# Altium schematic sheet GW1N.SchDoc(GW1N)
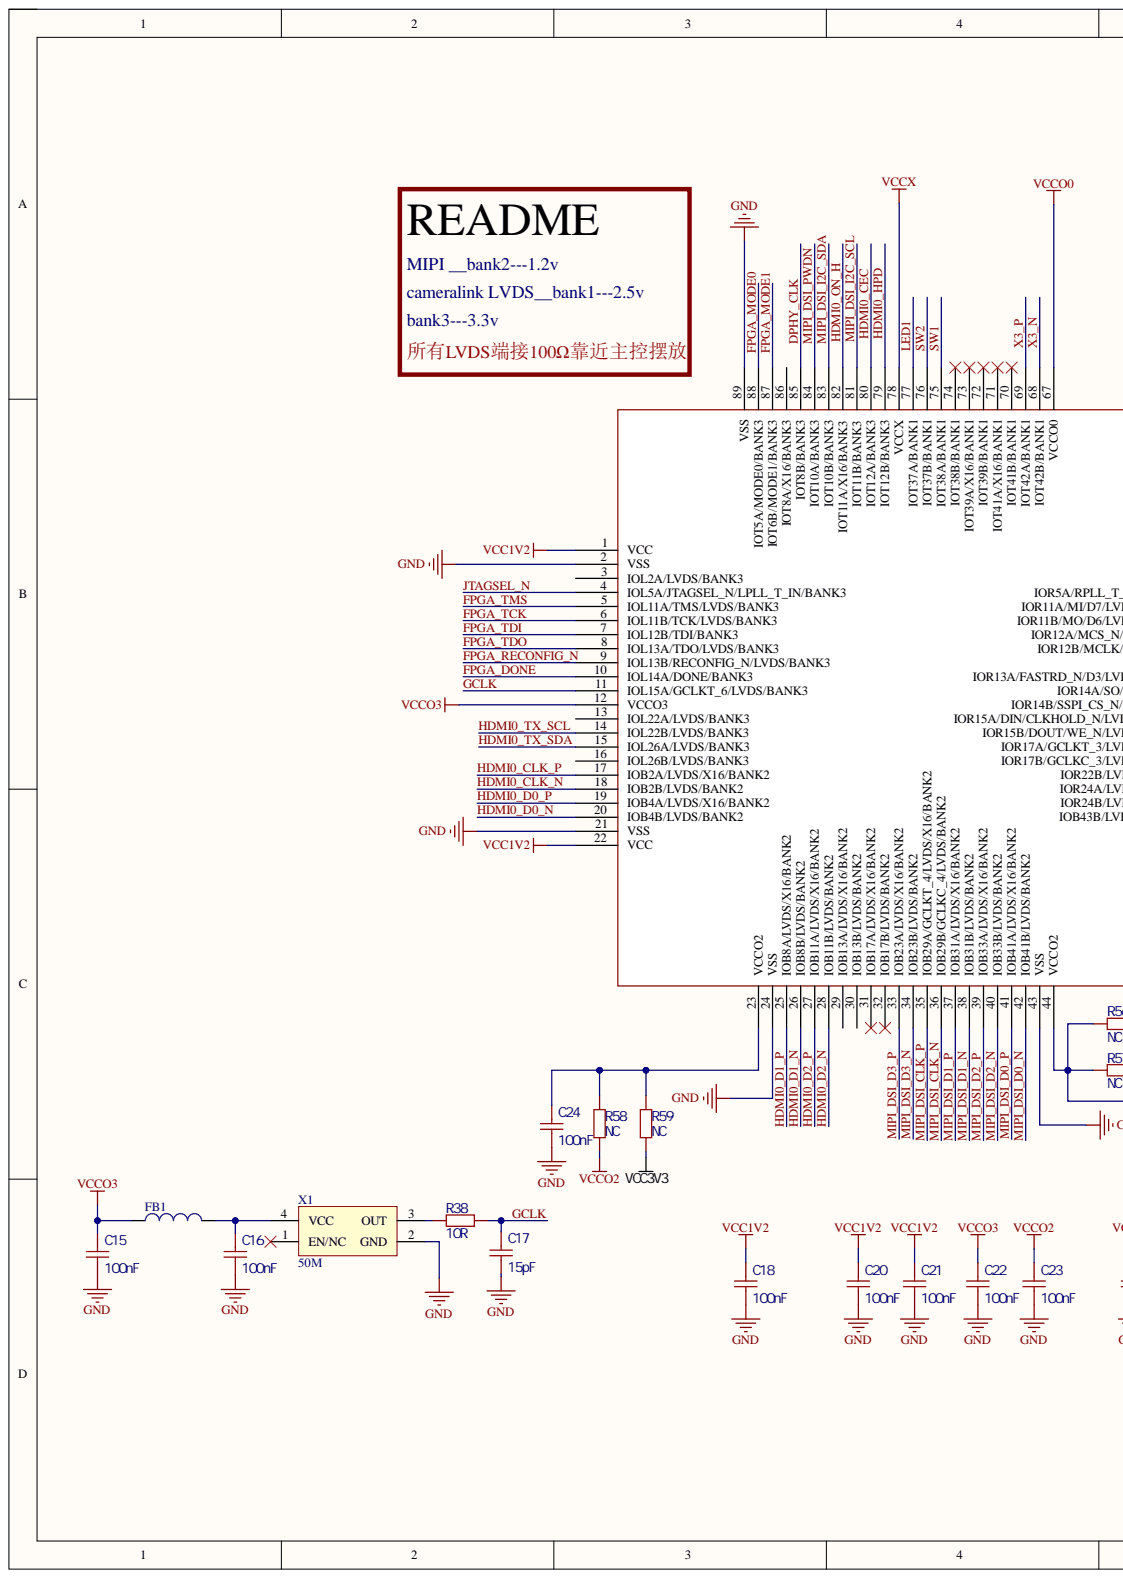
[diagram p0: GW1N.SchDoc, left]
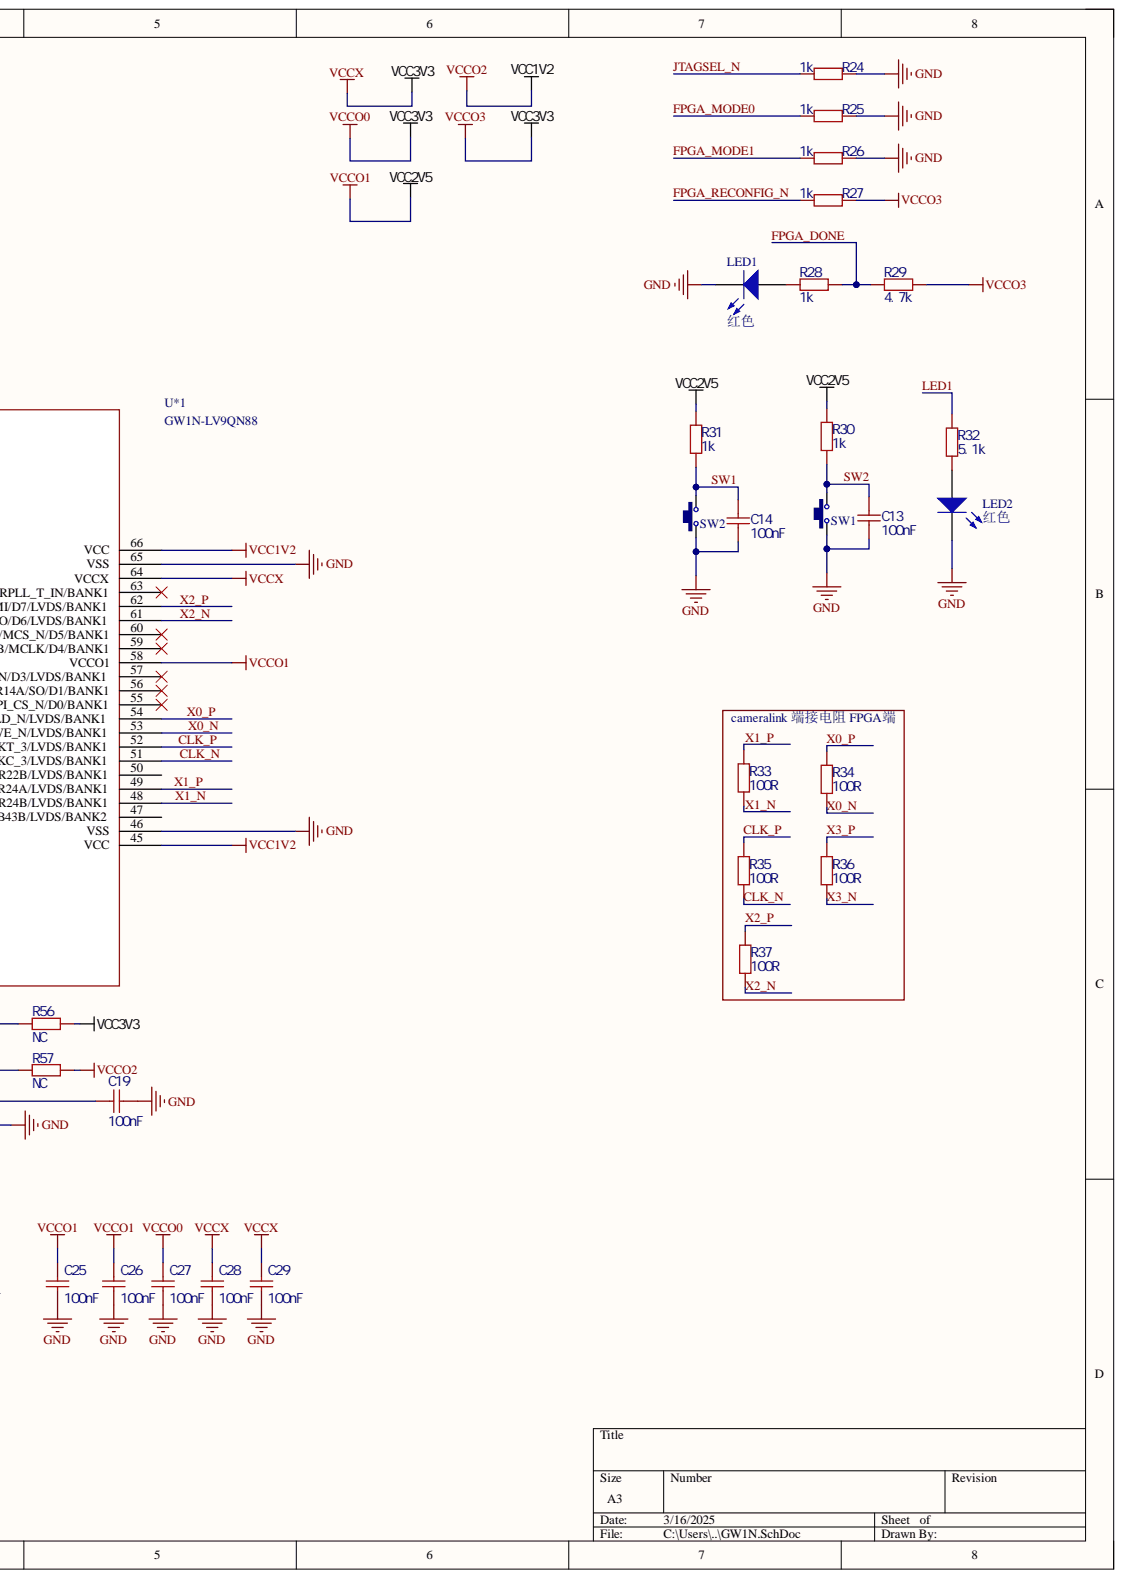
[diagram p1: GW1N.SchDoc, right]

## Parts
C13  100nF  [0402]
C14  100nF  [0402]
C15  100nF  [0402]
C16  100nF  [0402]
C17  15pF  [0402]
C18  100nF  [0402]
C19  100nF  [0402]
C20  100nF  [0402]
C21  100nF  [0402]
C22  100nF  [0402]
C23  100nF  [0402]
C24  100nF  [0402]
C25  100nF  [0402]
C26  100nF  [0402]
C27  100nF  [0402]
C28  100nF  [0402]
C29  100nF  [0402]
FB1  Inductor  [1608[0603]]  (value not drawn)
LED1  红色  [LED0805_G]
LED2  红色  [LED0805_G]
R24  1k  [0402]
R25  1k  [0402]
R26  1k  [0402]
R27  1k  [0402]
R28  1k  [0402]
R29  4.7k  [0402]
R30  1k  [0402]
R31  1k  [0402]
R32  5.1k  [0402]
R33  100R  [0402]
R34  100R  [0402]
R35  100R  [0402]
R36  100R  [0402]
R37  100R  [0402]
R38  10R  [0402]
R56  NC  [1608[0603]]
R57  NC  [1608[0603]]
R58  NC  [1608[0603]]
R59  NC  [1608[0603]]
SW1  按键  [SW-SMD_L6.0-W3.3-LS8.0]  (value not drawn)
SW2  按键  [SW-SMD_L6.0-W3.3-LS8.0]  (value not drawn)
U*1  GW1N-LV9QN88  [QFN-88_L10.0-W10.0-P0.40-BL-EP6.75]
X1  50M  [OSC-3225-4P]

## Nets
GND: C13.1 C14.1 C15.1 C16.1 C17.1 C18.1 C19.1 C20.1 C21.1 C22.1 C23.1 C24.1 C25.1 C26.1 C27.1 C28.1 C29.1 LED1.2 LED2.2 R24.2 R25.2 R26.2 SW1.1 SW2.1 U*1.2(VSS) U*1.21(VSS) U*1.24(VSS) U*1.43(VSS) U*1.46(VSS) U*1.65(VSS) U*1.89(VSS) X1.2(GND)
VCC1V2: C18.2 C20.2 C21.2 C23.2 R57.1 R58.1 U*1.1(VCC) U*1.22(VCC) U*1.45(VCC) U*1.66(VCC)
VCC2V5: C25.2 C26.2 R30.1 R31.1 U*1.58(VCCO1)
VCC3V3: C15.2 C22.2 C27.2 C28.2 C29.2 FB1.1 R27.2 R29.1 R56.1 R59.1 U*1.12(VCCO3) U*1.64(VCCX) U*1.67(VCCO0) U*1.78(VCCX)
CLK_N: R35.2 U*1.51(IOR17B/GCLKC_3/LVDS/BANK1)
CLK_P: R35.1 U*1.52(IOR17A/GCLKT_3/LVDS/BANK1)
DPHY_CLK: U*1.85(IOT8B/BANK3)
FPGA_DONE: R28.1 R29.2 U*1.10(IOL14A/DONE/BANK3)
FPGA_MODE0: R25.1 U*1.88(IOT5A/MODE0/BANK3)
FPGA_MODE1: R26.1 U*1.87(IOT6B/MODE1/BANK3)
FPGA_RECONFIG_N: R27.1 U*1.9(IOL13B/RECONFIG_N/LVDS/BANK3)
FPGA_TCK: U*1.6(IOL11B/TCK/LVDS/BANK3)
FPGA_TDI: U*1.7(IOL12B/TDI/BANK3)
FPGA_TDO: U*1.8(IOL13A/TDO/LVDS/BANK3)
FPGA_TMS: U*1.5(IOL11A/TMS/LVDS/BANK3)
GCLK: C17.2 R38.2 U*1.11(IOL15A/GCLKT_6/LVDS/BANK3)
HDMI0_CEC: U*1.80(IOT12A/BANK3)
HDMI0_CLK_N: U*1.18(IOB2B/LVDS/BANK2)
HDMI0_CLK_P: U*1.17(IOB2A/LVDS/X16/BANK2)
HDMI0_D0_N: U*1.20(IOB4B/LVDS/BANK2)
HDMI0_D0_P: U*1.19(IOB4A/LVDS/X16/BANK2)
HDMI0_D1_N: U*1.26(IOB8B/LVDS/BANK2)
HDMI0_D1_P: U*1.25(IOB8A/LVDS/X16/BANK2)
HDMI0_D2_N: U*1.28(IOB11B/LVDS/BANK2)
HDMI0_D2_P: U*1.27(IOB11A/LVDS/X16/BANK2)
HDMI0_HPD: U*1.79(IOT12B/BANK3)
HDMI0_ON_H: U*1.82(IOT11A/X16/BANK3)
HDMI0_TX_SCL: U*1.14(IOL22B/LVDS/BANK3)
HDMI0_TX_SDA: U*1.15(IOL26A/LVDS/BANK3)
JTAGSEL_N: R24.1 U*1.4(IOL5A/JTAGSEL_N/LPLL_T_IN/BANK3)
LED1: R32.1 U*1.77(IOT37A/BANK1)
MIPI_DSI_CLK_N: U*1.36(IOB29B/GCLKC_4/LVDS/BANK2)
MIPI_DSI_CLK_P: U*1.35(IOB29A/GCLKT_4/LVDS/X16/BANK2)
MIPI_DSI_D0_N: U*1.42(IOB41B/LVDS/BANK2)
MIPI_DSI_D0_P: U*1.41(IOB41A/LVDS/X16/BANK2)
MIPI_DSI_D1_N: U*1.38(IOB31B/LVDS/BANK2)
MIPI_DSI_D1_P: U*1.37(IOB31A/LVDS/X16/BANK2)
MIPI_DSI_D2_N: U*1.40(IOB33B/LVDS/BANK2)
MIPI_DSI_D2_P: U*1.39(IOB33A/LVDS/X16/BANK2)
MIPI_DSI_D3_N: U*1.34(IOB23B/LVDS/BANK2)
MIPI_DSI_D3_P: U*1.33(IOB23A/LVDS/X16/BANK2)
MIPI_DSI_I2C_SCL: U*1.81(IOT11B/BANK3)
MIPI_DSI_I2C_SDA: U*1.83(IOT10B/BANK3)
MIPI_DSI_PWDN: U*1.84(IOT10A/BANK3)
SW1: C14.2 R31.2 SW2.2 U*1.75(IOT38A/BANK1)
SW2: C13.2 R30.2 SW1.2 U*1.76(IOT37B/BANK1)
X0_N: R34.2 U*1.53(IOR15B/DOUT/WE_N/LVDS/BANK1)
X0_P: R34.1 U*1.54(IOR15A/DIN/CLKHOLD_N/LVDS/BANK1)
X1_N: R33.2 U*1.48(IOR24B/LVDS/BANK1)
X1_P: R33.1 U*1.49(IOR24A/LVDS/BANK1)
X2_N: R37.2 U*1.61(IOR11B/MO/D6/LVDS/BANK1)
X2_P: R37.1 U*1.62(IOR11A/MI/D7/LVDS/BANK1)
X3_N: R36.2 U*1.68(IOT42B/BANK1)
X3_P: R36.1 U*1.69(IOT42A/BANK1)
NetC16_2: C16.2 FB1.2 X1.4(VCC)
NetC19_2: C19.2 R56.2 R57.2 U*1.44(VCCO2)
NetC24_2: C24.2 R58.2 R59.2 U*1.23(VCCO2)
NetLED1_1: LED1.1 R28.2
NetLED2_1: LED2.1 R32.2
NetR38_1: R38.1 X1.3(OUT)

Not connected: U*1 3(IOL2A/LVDS/BANK3) 13(IOL22A/LVDS/BANK3) 16(IOL26B/LVDS/BANK3) 29(IOB13A/LVDS/X16/BANK2) 30(IOB13B/LVDS/BANK2) 31(IOB17A/LVDS/X16/BANK2) 32(IOB17B/LVDS/BANK2) 47(IOB43B/LVDS/BANK2) 50(IOR22B/LVDS/BANK1) 55(IOR14B/SSPI_CS_N/D0/BANK1) 56(IOR14A/SO/D1/BANK1) 57(IOR13A/FASTRD_N/D3/LVDS/BANK1) 59(IOR12B/MCLK/D4/BANK1) 60(IOR12A/MCS_N/D5/BANK1) 63(IOR5A/RPLL_T_IN/BANK1) 70(IOT41B/BANK1) 71(IOT41A/X16/BANK1) 72(IOT39B/BANK1) 73(IOT39A/X16/BANK1) 74(IOT38B/BANK1) 86(IOT8A/X16/BANK3); X1 1(EN/NC)

## Library data of the parts
C13-C16 C18-C29 = 0402B104K500NT: 容值:100nF;精度:±10%;额定电压:50V;材质(温度系数):X7R;; Manufacturer: FH(风华); Add into BOM: yes; Temperature Coefficient: X7R; Tolerance: ±10%; Voltage Rated: 50V; Component Kind: Standard; Convert to PCB: yes; Datasheet: https://atta.szlcsc.com/upload/public/pdf/source/20200305/C486355_26A10D38EF8C2A5C37091D0039909270.pdf; Device: 0402B104K500NT; JLCPCB Part Class: 扩展库; LCSC Part Name: 100nF ±10% 50V; Manufacturer Part: 0402B104K500NT; PCB3D: C0402_L1.0-W0.5-H0.5; Supplier: LCSC; Supplier Footprint: 0402; Supplier Part: C110251; Symbol: 0402B104K500NT; Value: 100nF
C17 = 0402B104K500NT: 容值:100nF;精度:±10%;额定电压:50V;材质(温度系数):X7R;; Manufacturer: FH(风华); Add into BOM: yes; Temperature Coefficient: X7R; Tolerance: ±10%; Value: 100nF; Voltage Rated: 50V; Component Kind: Standard; Convert to PCB: yes; Datasheet: https://atta.szlcsc.com/upload/public/pdf/source/20200305/C486355_26A10D38EF8C2A5C37091D0039909270.pdf; Device: 0402B104K500NT; JLCPCB Part Class: 扩展库; LCSC Part Name: 100nF ±10% 50V; Manufacturer Part: 0402B104K500NT; PCB3D: C0402_L1.0-W0.5-H0.5; Supplier: LCSC; Supplier Footprint: 0402; Supplier Part: C110251; Symbol: 0402B104K500NT
FB1 = Inductor: Code_IEC: 1005; Code_JEITA: 0402; Component Kind: Standard; Description: Inductor; LatestRevisionDate: 29-May-2009; LatestRevisionNote: IPC-7351 Footprint Added.; Library Name: Miscellaneous Devices.IntLib; PackageDescription: Chip Inductor; PackageReference: 0402-A; Published: 8-Jun-2000; Signal Integrity: Inductor; Simulation: INDUCTOR; Publisher: Altium Limited
LED1 LED2 = LED: 贴片LED; 封装库来自淘宝卖家：黄晶宝石: 其他店铺购入均为盗版封装库，请立即申请退款，本店现金奖励200~1000元; BOM名称: 贴片LED; Component Kind: Standard; Library Name: 0.3 - LED发光二极管-IR二极管_接收管-光耦.SchLib
R24-R38 R56-R59 = RC-02K4422FT: 电阻类型:厚膜电阻;阻值:44.2kΩ;精度:±1%;功率:62.5mW;最大工作电压:50V;温度系数:±100ppm/℃;; Manufacturer: FH(风华); Add into BOM: yes; Overload Voltage (Max): 50V; Power(Watts): 62.5mW; Symbol: RS-02K4422FT; Tolerance: ±1%; Value: 44.2kΩ; Component Kind: Standard; Convert to PCB: yes; Datasheet: https://atta.szlcsc.com/upload/public/pdf/source/20140616/1457707091974.pdf; Device: RC-02K4422FT; JLCPCB Part Class: 扩展库; LCSC Part Name: 厚膜电阻 44.2kΩ ±1% 62.5mW; Manufacturer Part: RC-02K4422FT; Operating Temperature Range: -55℃~+155℃; PCB3D: R0402_L1.0-W0.5-H0.5; Supplier: LCSC; Supplier Footprint: 0402; Supplier Part: C140153; Temperature Coefficient: ±100ppm/℃; Type: 厚膜电阻; Library Name: schdoc1.SCHLIB
X1 = XTAL-ACT-4P: 4脚有源晶振(振荡器); 联系下单店铺卖家 免费帮你查找封装: 联系下单店铺卖家 免费技术支持; BOM名称: 有源晶振(振荡器); Component Kind: Standard; Library Name: 0.1 - 电阻-电容-电感-晶振-晶体-磁珠-电位器-法拉电容-馈通电容.SchLib
SW1 SW2 = 按键: Component Kind: Standard; Library Name: FPGA_EP4CE10.SchLib
U*1 = GW1N-LV9QN88: Component Kind: Standard; Library Name: schdoc1.SCHLIB
